# Altium schematic sheet gateway.SchDoc(gateway)
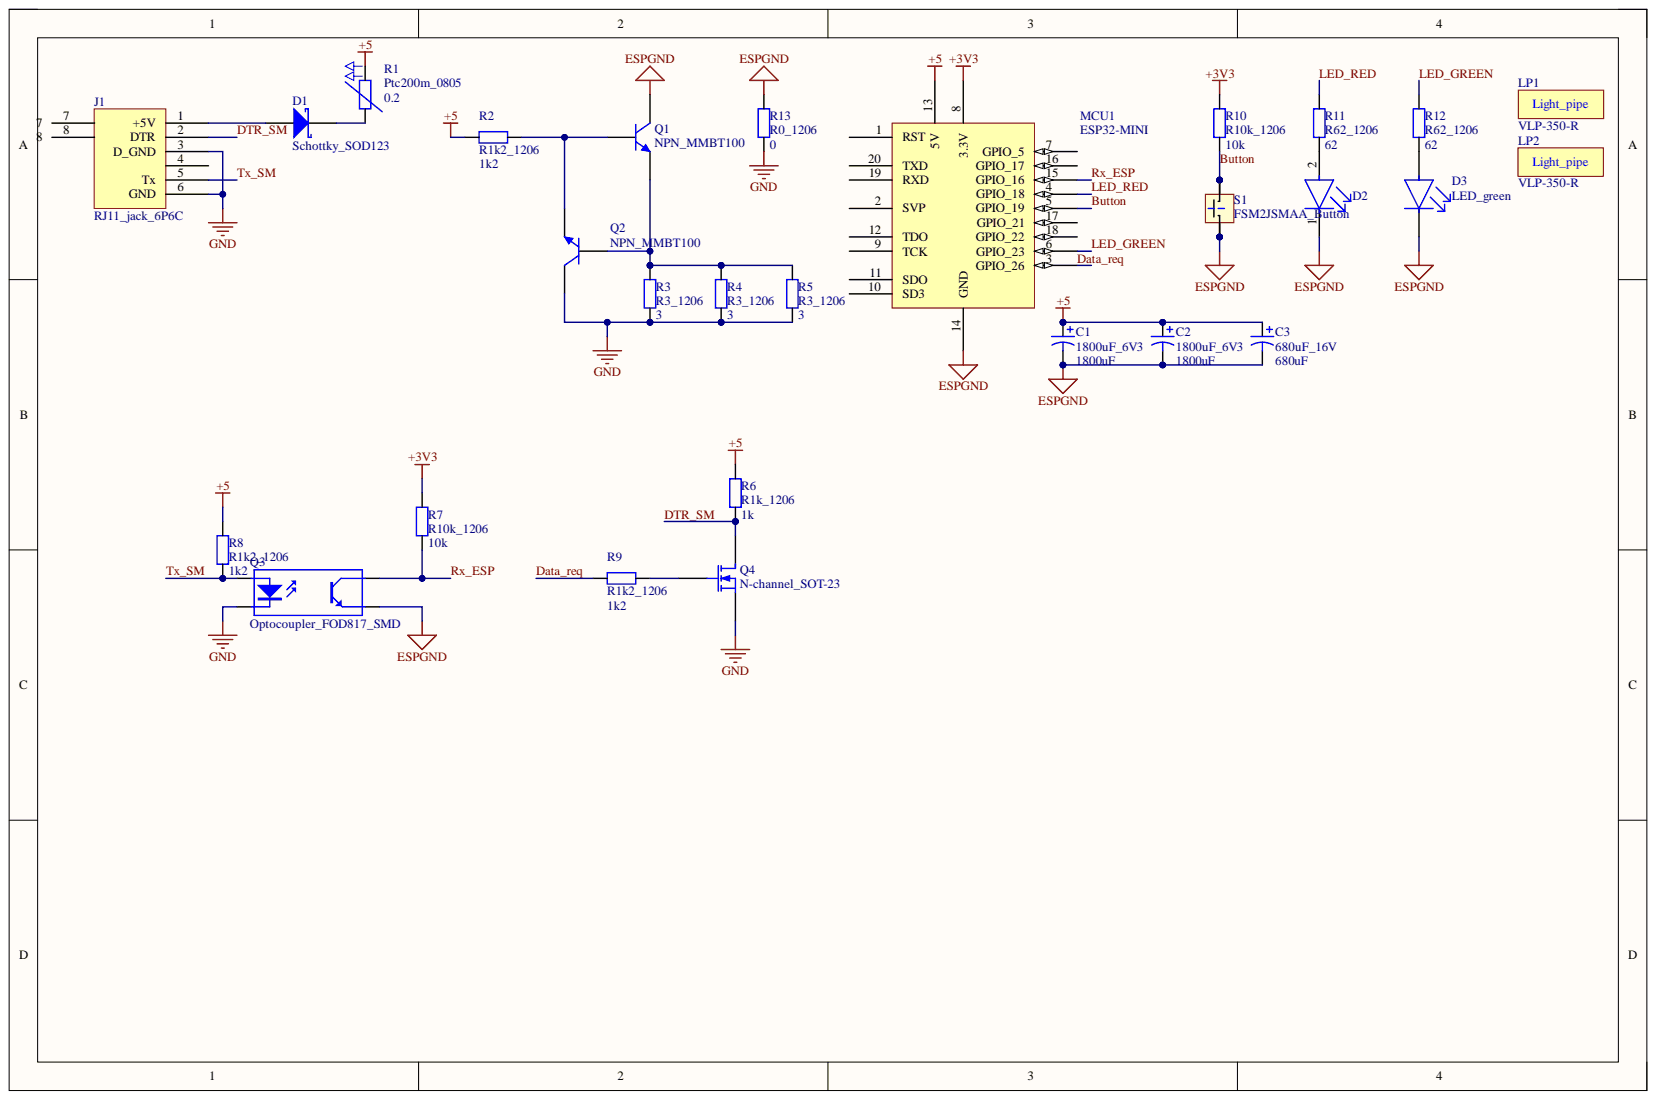
[diagram p0: gateway.SchDoc]

## Parts
C1  1800uF_6V3  value 1800uF  [CAPAE109103X105M_680uF]
C2  1800uF_6V3  value 1800uF  [CAPAE109103X105M_680uF]
C3  680uF_16V  value 680uF  [CAPAE109103X105M_680uF]
D1  Schottky_SOD123  [Diode_SOD-123]
D2  red led  [Kingbright_KPT-2012EC_0]  (value not drawn)
D3  LED_green  [Kingbright_KPT-2012EC_0]
J1  RJ11_jack_6P6C  [44144-0005]
LP1  VLP-350-R  [VLP-350-R]
LP2  VLP-350-R  [VLP-350-R]
MCU1  ESP32-MINI  [PINHEADERS_SMD_10]
Q1  NPN_MMBT100  [SOT95P245X110-3N]
Q2  NPN_MMBT100  [SOT95P245X110-3N]
Q3  Optocoupler_FOD817_SMD  [FOD814_DIPSMD]
Q4  N-channel_SOT-23  [SOT95P237X110-3N]
R1  Ptc200m_0805  value 0.2  [R0805]
R2  R1k2_1206  value 1k2  [R1206]
R3  R3_1206  value 3  [R1206]
R4  R3_1206  value 3  [R1206]
R5  R3_1206  value 3  [R1206]
R6  R1k_1206  value 1k  [R1206]
R7  R10k_1206  value 10k  [R1206]
R8  R1k2_1206  value 1k2  [R1206]
R9  R1k2_1206  value 1k2  [R1206]
R10  R10k_1206  value 10k  [R1206]
R11  R62_1206  value 62  [R1206]
R12  R62_1206  value 62  [R1206]
R13  R0_1206  value 0  [R1206]
S1  FSM2JSMAA_Button  [FP-FSM2JSMAA-MFG]

## Nets
+3V3: MCU1.8(3.3V) R7.2 R10.2
+5: C1.1 C2.1 C3.1 MCU1.13(5V) R1.2 R2.1 R6.2 R8.2
ESPGND: C1.2 C2.2 C3.2 D2.1 D3.1 MCU1.14(GND) Q1.3 Q3.3 R13.2 S1.1 S1.3
GND: J1.3(D_GND) J1.6(GND) Q2.3 Q3.2 Q4.3 R3.1 R4.1 R5.1 R13.1
Button: MCU1.5(GPIO_19) R10.1 S1.2 S1.4
Data_req: MCU1.3(GPIO_26) R9.1
DTR_SM: J1.2(DTR) Q4.1 R6.1
LED_GREEN: MCU1.6(GPIO_23) R12.2
LED_RED: MCU1.4(GPIO_18) R11.2
Rx_ESP: MCU1.15(GPIO_16) Q3.4 R7.1
Tx_SM: J1.5(Tx) Q3.1 R8.1
NetD1_1: D1.1 R1.1
NetD1_2: D1.2 J1.1(+5V)
NetD2_2: D2.2 R11.1
NetD3_2: D3.2 R12.1
NetQ1_1: Q1.1 Q2.2 R3.2 R4.2 R5.2
NetQ1_2: Q1.2 Q2.1 R2.2
NetQ4_2: Q4.2 R9.2

Not connected: J1 4 7 8; MCU1 1(RST) 2(SVP) 7(GPIO_5) 9(TCK) 10(SD3) 11(SDO) 12(TDO) 16(GPIO_17) 17(GPIO_21) 18(GPIO_22) 19(RXD) 20(TXD)

## Library data of the parts
C1 C2 = 1800uF_6V3: SMD Aluminium Electrolytic Capacitor, Radial Can, 1800 µF, 10 V, 0.092 ohm, TRV Series; Manufacturer: Panasonic; Manufacturer Part Number: EEE-FN0J182UV; Capacitance: 1.8mF; Voltage Rating: 10V; Category: Aluminum Electrolytic Capacitors; Component Kind: Standard; Library Name: Capacitor.SchLib; Mount: Surface Mount; Supplier 1: Mouser; Supplier Part Number 1: 667-EEE-FN0J182UV; Supplier Unit Price 1: €0.98708
C3 = 680uF_16V: Aluminum Electrolytic Capacitors - SMD LOW ESR ELECTROLYTIC CAPACITORS; Manufacturer: Rubycon; Manufacturer Part Number: 16TZV680M10X10.5; Voltage Rating: 10V; Case/Package: Radial; Category: Aluminum Electrolytic Capacitors; Component Kind: Standard; Library Name: Capacitor.IntLib; Mount: Surface Mount; Supplier 1: Mouser; Supplier Part Number 1: 232-16TZV680M10X10.5; Supplier Unit Price 1: €0.68594
D1 = Schottky_SOD123: Schottky Rectifier, 20 V, 500 mA, Single, SOD-123, 2 Pins, 320 mV; Manufacturer: STMicroelectronics; Manufacturer Part Number: STPS0520Z; Forward Current: 500mA; Forward Voltage: 320mV; Max Reverse Voltage (DC): 20V; Peak Non-Repetitive Surge Current: 5.5A; Category: Rectifier Diodes; Component Kind: Standard; Library Name: Diodes.IntLib; Mount: Surface Mount; Output Current: 500mA; Supplier 1: Mouser; Supplier Part Number 1: 511-STPS0520Z; Supplier Unit Price 1: €0.21607
D2 = KPT-2012EC: KPT-2012EC -  LED, Low Power, Red, SMD, 0805 [2012 Metric], 30 mA, 2 V, 617 nm; Manufacturer: Kingbright; Manufacturer Part Number: AP2012SRCPRV; Forward Current: 20mA; Forward Voltage: 1.85V; Case/Package: 0805; Category: LEDs; Color: Red; Component Kind: Standard; Illumination Color: Red; Library Name: Button_led.IntLib; Mount: Surface Mount; Supplier 1: Mouser; Supplier Part Number 1: 604-AP2012SRCPRV
D3 = LED_green: Standard LEDs - SMD GRN WATER CLEAR 0805; Manufacturer: Kingbright; Manufacturer Part Number: AP2012MGC; Color: Green; Forward Current: 20mA; Forward Voltage: 2.1V; Case/Package: 0805; Category: LEDs; Component Kind: Standard; Library Name: Button_led.IntLib; Supplier 1: Mouser; Supplier Part Number 1: 604-AP2012MGC
J1 = RJ11_jack_6P6C: Modular Connector, RJ11 Jack, 1 x 1 (Port), 6P6C, Cat3, Surface Mount; Manufacturer: Molex; Gender: Female; Category: Modular / Ethernet Connectors; Component Kind: Standard; Library Name: Connectors.SchLib; Manufacturer Part Number: 44144-0005; Mount: Surface Mount; Supplier 1: Mouser; Supplier Packaging 1: Cut Tape; Supplier Part Number 1: 538-44144-0005; Supplier Unit Price 1: €1.14; Termination: SMD/SMT
LP1 LP2 = VLP-350-R: LIGHT PIPE, SINGLE, ROUND, PCB; Manufacturer: BIVAR; Component Kind: Standard; Library Name: Button_led.IntLib; Manufacturer Part Number: VLP-350-R
MCU1 = ESP32-MINI: LilyGO TTGO T7 Mini32 V1.4 ESP32-WROVER; Manufacturer Part Number: 10129380-910002ALF; Component Kind: Standard; ComponentLink1Description: Manufacturer; ComponentLink1URL: https://www.tinytronics.nl/shop/nl/platforms/ttgo/lilygo-ttgo-t7-mini32-v1.4-esp32-wrover; Library Name: mcu.SchLib; Supplier 1: Mouser; Supplier Part Number 1: 649-1012938091002ALF; Supplier Unit Price 1: €0.23406
Q1 Q2 = NPN_MMBT100: Bipolar (BJT) Single Transistor, NPN, 45 V, 500 mA, 350 mW, SOT-23, Surface Mount; Manufacturer: ON Semiconductor / Fairchild; Collector Emitter Voltage (VCEO): 45V; Emitter Base Voltage (VEBO): 6V; hFE Min: 100; Max Collector Current: 500mA; Max Frequency: 1MHz; Max Power Dissipation: 350mW; Category: BJTs; Component Kind: Standard; Library Name: Transistor.IntLib; Manufacturer Part Number: MMBT100; Max Breakdown Voltage: 45V; Mount: Surface Mount; Polarity: NPN; Power Dissipation: 350mW; Supplier 1: Mouser; Supplier Part Number 1: 512-MMBT100; Supplier Unit Price 1: €0.15259; Voltage Rating (DC): 45V
Q3 = Optocoupler_FOD817_SMD: Optocoupler, Transistor Output, 1 Channel, Surface Mount DIP, 4 Pins, 50 mA, 5 kV, 200 %; Manufacturer: ON Semiconductor / Fairchild; Manufacturer Part Number: FOD817C3SD; Forward Voltage: 1.4V; Max Power Dissipation: 200mW; Case/Package: SMD/SMT; Category: Optocouplers; Component Kind: Standard; Library Name: Transistor.IntLib; Mount: Surface Mount; Supplier 1: Mouser; Supplier Part Number 1: 512-FOD817C3SD; Supplier Unit Price 1: €0.45665
Q4 = N-channel_SOT-23: Power MOSFET, N Channel, 60 V, 260 mA, 1 ohm, SOT-23, Surface Mount; Manufacturer: ON Semiconductor; Manufacturer Part Number: NTR5103NT1G; FET Type: 1 N-Channel; Max Power Dissipation: 300mW; Case/Package: SOT-23-3; Category: MOSFETs; Component Kind: Standard; Library Name: Mosfet.SchLib; Mount: Surface Mount; Supplier 1: Mouser; Supplier Part Number 1: 863-NTR5103NT1G; Supplier Unit Price 1: €0.14925
R1 = Ptc200m_0805: Thermistor, PTC, SMD, 0805, 0.2 OHM; Manufacturer: Murata; Manufacturer Part Number: PRG21BC0R2MM1RA; Hold Current: 500mA; Resistance: 200mR; Tolerance: 20%; Case Code (Imperial): 0805; Case Code (Metric): 2012; Case/Package: 0805; Category: PTC Resettable Fuses; Component Kind: Standard; Library Name: Resistor.SchLib; Mount: Surface Mount; Supplier 1: Mouser; Supplier Part Number 1: 81-PRG21BC0R2MM1RA; Supplier Unit Price 1: €0.44997; Termination: SMD/SMT
R2 R8 R9 = R1k2_1206: Thick Film Resistors - SMD 1206 1.2Kohms 1% AEC-Q200; Manufacturer: Panasonic; Manufacturer Part Number: ERJ-8ENF1201V; Max Power Dissipation: 250mW; Resistance: 1.2kR; Case Code (Imperial): 1206; Case/Package: 1206; Category: Chip SMD Resistors; Component Kind: Standard; Library Name: Resistor.IntLib; Mount: Surface Mount; Power Rating: 250mW; Supplier 1: Mouser; Supplier Part Number 1: 667-ERJ-8ENF1201V; Supplier Unit Price 1: €0.08359; Tolerance: 1%
R3-R5 = R3_1206: Current Sense Resistors - SMD 1206 3.0ohm 1% Curr Sense AEC-Q200; Manufacturer: Panasonic; Manufacturer Part Number: ERJ8RQF3R0V; Power Rating: 250mW; Case Code (Imperial): 1206; Case Code (Metric): 3216; Case/Package: 1206; Category: Chip SMD Resistors; Component Kind: Standard; Library Name: Resistor.IntLib; Mount: Surface Mount; Supplier 1: Mouser; Supplier Part Number 1: 667-ERJ-8RQF3R0V; Supplier Unit Price 1: €0.45139; Tolerance: 1%
R6 = R1k_1206: Thick Film Resistors - SMD 1206 1Kohms 1% Tol AEC-Q200; Manufacturer: Panasonic; Manufacturer Part Number: ERJ-8ENF1001V; Max Power Dissipation: 250mW; Case Code (Imperial): 1206; Case Code (Metric): 3216; Case/Package: 1206; Category: Chip SMD Resistors; Component Kind: Standard; Library Name: Resistor.IntLib; Mount: Surface Mount; Power Rating: 250mW; Supplier 1: Mouser; Supplier Part Number 1: 667-ERJ-8ENF1001V; Supplier Unit Price 1: €0.01325; Tolerance: 1%
R7 R10 = R10k_1206: Thick Film Resistors - SMD 1206 10Kohms 1% AEC-Q200; Manufacturer: Panasonic; Manufacturer Part Number: ERJ-8ENF1002V; Max Power Dissipation: 250mW; Case Code (Imperial): 1206; Case Code (Metric): 3216; Case/Package: 1206; Category: Chip SMD Resistors; Component Kind: Standard; Library Name: Resistor.IntLib; Mount: Surface Mount; Power Rating: 250mW; Supplier 1: Mouser; Supplier Part Number 1: 667-ERJ-8ENF1002V; Supplier Unit Price 1: €0.01214; Tolerance: 1%
R11 R12 = R62_1206: Thick Film Resistors - SMD 1206 62ohms 1% AEC-Q200; Manufacturer: Panasonic; Manufacturer Part Number: ERJ-8ENF62R0V; Max Power Dissipation: 250mW; Case Code (Imperial): 1206; Case Code (Metric): 3216; Case/Package: 1206; Category: Chip SMD Resistors; Component Kind: Standard; Library Name: Resistor.IntLib; Mount: Surface Mount; Supplier 1: Mouser; Supplier Part Number 1: 667-ERJ-8ENF62R0V; Supplier Unit Price 1: €0.00512; Tolerance: 1%
S1 = FSM2JSMAA_Button: Tactile Switch, FSMJSM Series, Top Actuated, Surface Mount, Round Button, 260 gf, 50mA at 24VDC; Manufacturer: TE Connectivity; Connector Type: SMD/SMT; Voltage Rating (DC): 24V; Case/Package: SMD/SMT; Category: Pushbutton Switches; Component Kind: Standard; Library Name: Button_led.SchLib; Manufacturer Part Number: FSM2JSMAA; Mount: Surface Mount; Supplier 1: Mouser; Supplier Part Number 1: 506-2-1437565-8; Supplier Unit Price 1: €0.13022
R13 = R0_1206: Component Kind: Standard; Library Name: Resistor.IntLib
